# Altium schematic sheet Şematik_teknofestt_2022_Drone.SchDoc
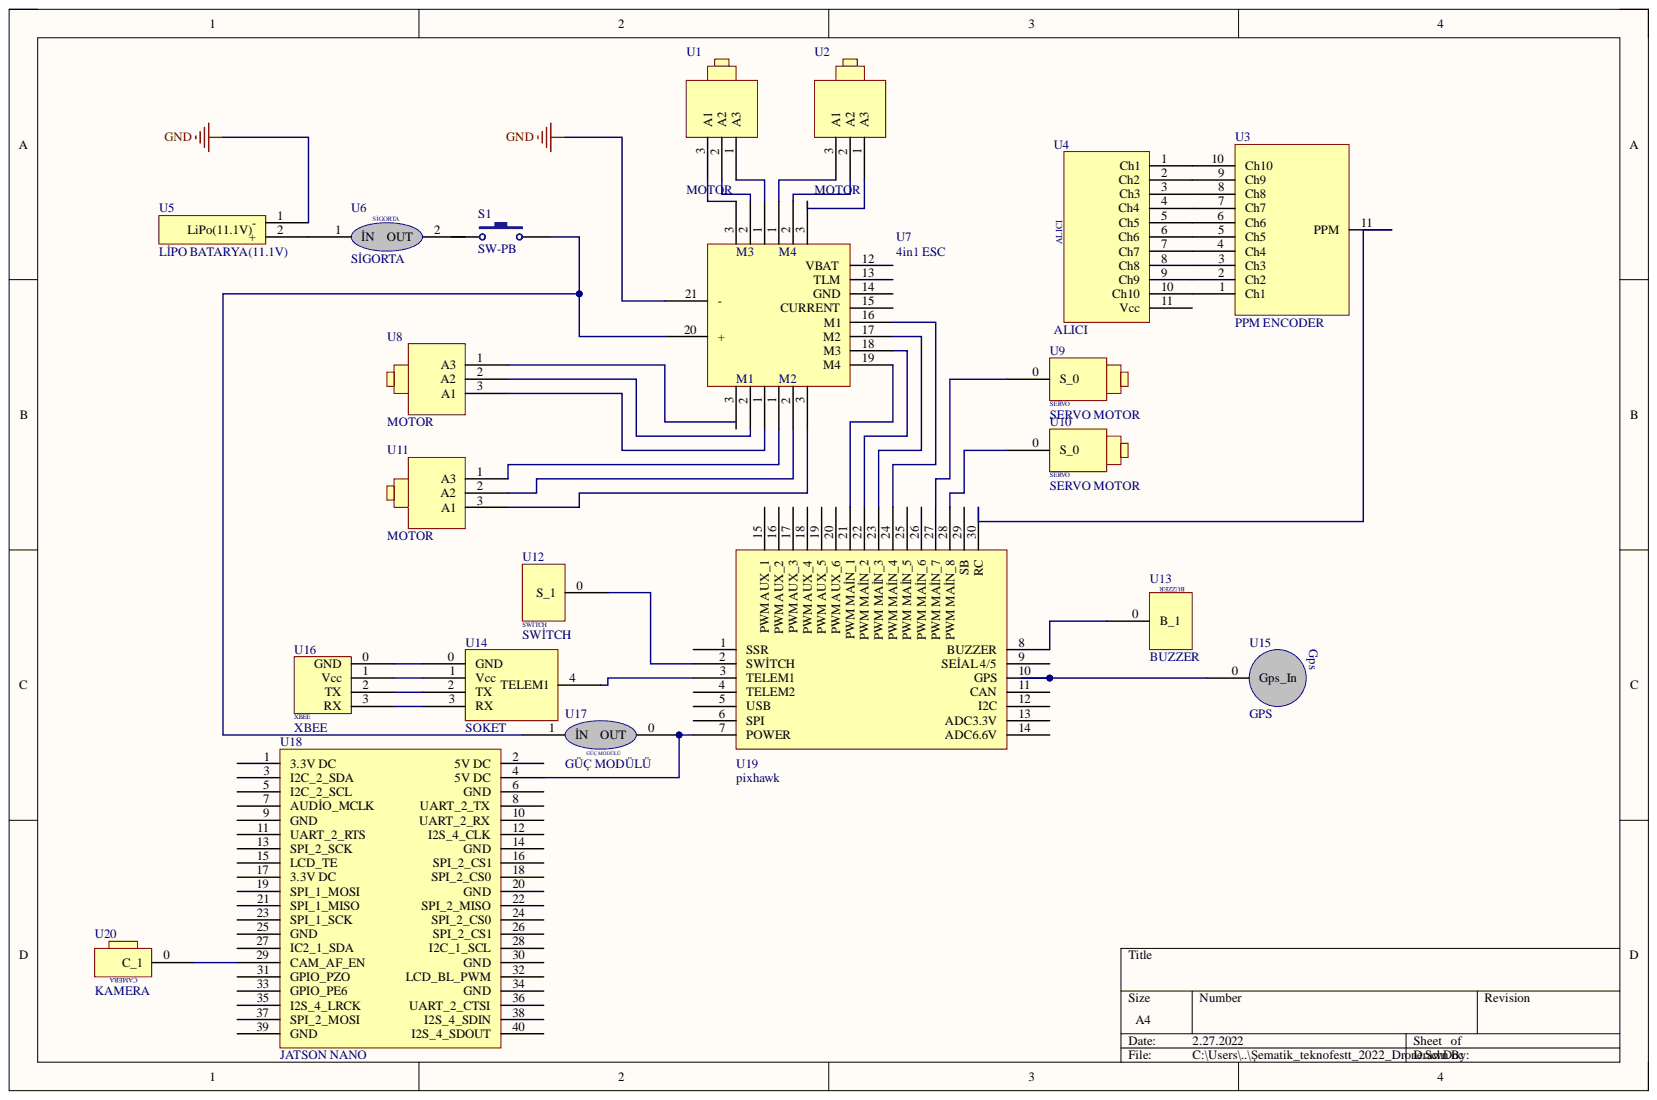
[diagram p0: Şematik_teknofestt_2022_Drone.SchDoc]

## Parts
S1  SW-PB  [SPST-2]
U1  MOTOR
U2  MOTOR
U3  PPM ENCODER
U4  ALICI
U5  LİPO BATARYA(11.1V)
U6  SİGORTA
U7  4in1 ESC
U8  MOTOR
U9  SERVO MOTOR
U10  SERVO MOTOR
U11  MOTOR
U12  SWİTCH
U13  BUZZER
U14  SOKET
U15  GPS
U16  XBEE
U17  GÜÇ MODÜLÜ
U18  JATSON NANO
U19  pixhawk
U20  KAMERA

## Nets
GND: U5.1 U7.21(-)
NetS1_2: S1.2 U7.20(+) U17.1
NetU1_1: U1.1 U7.1
NetU1_2: U1.2 U7.2
NetU1_3: U1.3 U7.3
NetU2_1: U2.1 U7.3
NetU2_2: U2.2 U7.2
NetU2_3: U2.3 U7.1
NetU3_1: U3.1(Ch1) U4.10(Ch10)
NetU3_2: U3.2(Ch2) U4.9(Ch9)
NetU3_3: U3.3(Ch3) U4.8(Ch8)
NetU3_4: U3.4(Ch4) U4.7(Ch7)
NetU3_5: U3.5(Ch5) U4.6(Ch6)
NetU3_6: U3.6(Ch6) U4.5(Ch5)
NetU3_7: U3.7(Ch7) U4.4(Ch4)
NetU3_8: U3.8(Ch8) U4.3(Ch3)
NetU3_9: U3.9(Ch9) U4.2(Ch2)
NetU3_10: U3.10(Ch10) U4.1(Ch1)
NetU3_11: U3.11 U19.30(RC)
NetU5_2: U5.2 U6.1
NetU7_1: U7.1 U8.3 U11.1
NetU7_2: U7.2 U8.2 U11.2
NetU7_3: U7.3 U11.3
NetU7_16: U7.16(M1) U19.24(PWM_MAİN_4)
NetU7_17: U7.17(M2) U19.23(PWM_MAİN_3)
NetU7_18: U7.18(M3) U19.22(PWM_MAİN_2)
NetU7_19: U7.19(M4) U19.21(PWM_MAİN_1)
NetU9_0: U9.0 U19.27(PWM_MAİN_7)
NetU10_0: U10.0 U19.28(PWM_MAİN_8)
NetU12_0: U12.0 U19.2(SWİTCH)
NetU13_0: U13.0 U19.8(BUZZER)
NetU14_0: U14.0(GND) U16.0(GND)
NetU14_1: U14.1(Vcc) U16.1(Vcc)
NetU14_2: U14.2(TX) U16.2(TX)
NetU14_3: U14.3(RX) U16.3(RX)
NetU14_4: U14.4(TELEM1) U19.3(TELEM1)
NetU15_0: U15.0 U19.10(GPS)
NetU17_0: U17.0 U18.4(5V_DC) U19.7(POWER)
NetU18_29: U18.29(CAM_AF_EN) U20.0

Not connected: S1 1; U4 11(Vcc); U6 2; U7 12(VBAT) 13(TLM) 14(GND) 15(CURRENT); U8 1; U18 1(3.3V_DC) 2(5V_DC) 3(I2C_2_SDA) 5(I2C_2_SCL) 6(GND) 7(AUDİO_MCLK) 8(UART_2_TX) 9(GND) 10(UART_2_RX) 11(UART_2_RTS) 12(I2S_4_CLK) 13(SPI_2_SCK) 14(GND) 15(LCD_TE) 16(SPI_2_CS1) 17(3.3V_DC) 18(SPI_2_CS0) 19(SPI_1_MOSI) 20(GND) 21(SPI_1_MISO) 22(SPI_2_MISO) 23(SPI_1_SCK) 24(SPI_2_CS0) 25(GND) 26(SPI_2_CS1) 27(IC2_1_SDA) 28(I2C_1_SCL) 30(GND) 31(GPIO_PZO) 32(LCD_BL_PWM) 33(GPIO_PE6) 34(GND) 35(I2S_4_LRCK) 36(UART_2_CTSI) 37(SPI_2_MOSI) 38(I2S_4_SDIN) 39(GND) 40(I2S_4_SDOUT); U19 1(SSR) 4(TELEM2) 5(USB) 6(SPI) 9(SEİAL_4/5) 11(CAN) 12(I2C) 13(ADC3.3V) 14(ADC6.6V) 15(PWM_AUX_1) 16(PWM_AUX_2) 17(PWM_AUX_3) 18(PWM_AUX_4) 19(PWM_AUX_5) 20(PWM_AUX_6) 25(PWM_MAİN_5) 26(PWM_MAİN_6) 29(SB)

## Library data of the parts
S1 = SW-PB: Switch; Component Kind: Standard; LatestRevisionDate: 17-Jul-2002; LatestRevisionNote: Re-released for DXP Platform.; Library Name: Miscellaneous Devices.IntLib; PackageDescription: Switch; 2 Leads; PackageReference: SPST-2; Published: 24-Mar-1999; Publisher: Altium Limited
U1 U2 U8 U11 = MOTOR: Component Kind: Standard; Library Name: Schlib1_TEKNOFEST.SchLib
U3 = PPM ENCODER: Component Kind: Standard; Library Name: Schlib1_TEKNOFEST.SchLib
U4 = ALICI: Component Kind: Standard; Library Name: Schlib1_TEKNOFEST.SchLib
U5 = LİPO BATARYA(11.1V): Component Kind: Standard; Library Name: Schlib1_TEKNOFEST.SchLib
U6 = SİGORTA: Component Kind: Standard; Library Name: Schlib1_TEKNOFEST.SchLib
U7 = 4in1 ESC: Component Kind: Standard; Library Name: Schlib1_TEKNOFEST.SchLib
U9 U10 = SERVO MOTOR: Component Kind: Standard; Library Name: Schlib1_TEKNOFEST.SchLib
U12 = SWİTCH: Component Kind: Standard; Library Name: Schlib1_TEKNOFEST.SchLib
U13 = BUZZER: Component Kind: Standard; Library Name: Schlib1_TEKNOFEST.SchLib
U14 = SOKET: Component Kind: Standard; Library Name: Schlib1_TEKNOFEST.SchLib
U15 = GPS: Component Kind: Standard; Library Name: Schlib1_TEKNOFEST.SchLib
U16 = XBEE: Component Kind: Standard; Library Name: Schlib1_TEKNOFEST.SchLib
U17 = GÜÇ MODÜLÜ: Component Kind: Standard; Library Name: Schlib1_TEKNOFEST.SchLib
U18 = JATSON NANO: Component Kind: Standard; Library Name: Schlib1_TEKNOFEST.SchLib
U19 = pixhawk: Component Kind: Standard; Library Name: Schlib1_TEKNOFEST.SchLib
U20 = KAMERA: Component Kind: Standard; Library Name: Schlib1_TEKNOFEST.SchLib
